# Altium schematic sheet 04_AudioCodec.SchDoc
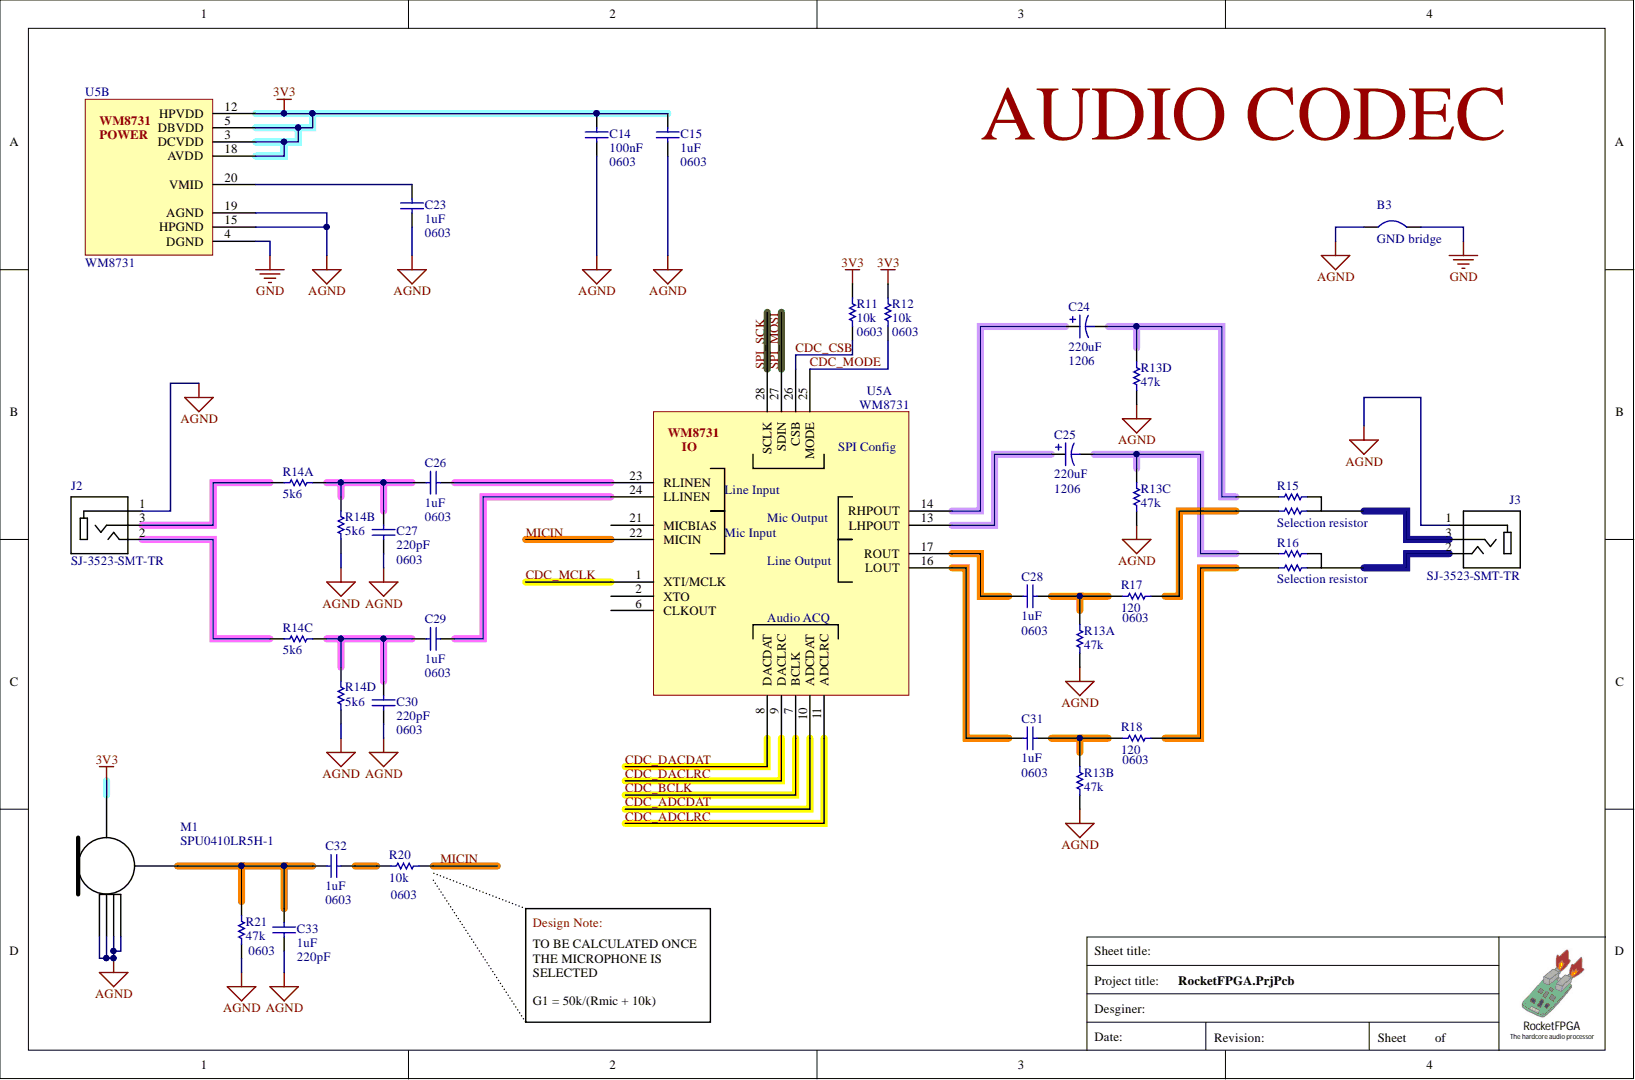
[diagram p0: 04_AudioCodec.SchDoc]

## Parts
B3  GND bridge  [Bridge]
C14  value 100nF  Package: 0603  [0603 CAP]  (hidden comment: Ceramic capacitor)
C15  value 1uF  Package: 0603  [0603 CAP]  (hidden comment: Ceramic capacitor)
C23  value 1uF  Package: 0603  [0603 CAP]  (hidden comment: Ceramic capacitor)
C24  value 220uF  Package: 1206  [CAPCP3216X16N]  (hidden comment: Capacitor Electrolitic)
C25  value 220uF  Package: 1206  [CAPCP3216X16N]  (hidden comment: Capacitor Electrolitic)
C26  value 1uF  [0603 CAP]  (hidden comment: Ceramic capacitor)
C27  value 220pF  Package: 0603  [0603 CAP]  (hidden comment: Ceramic capacitor)
C28  value 1uF  Package: 0603  [0603 CAP]  (hidden comment: Ceramic capacitor)
C29  value 1uF  Package: 0603  [0603 CAP]  (hidden comment: Ceramic capacitor)
C30  value 220pF  Package: 0603  [0603 CAP]  (hidden comment: Ceramic capacitor)
C31  value 1uF  Package: 0603  [0603 CAP]  (hidden comment: Ceramic capacitor)
C32  value 1uF  Package: 0603  [0603 CAP]  (hidden comment: Ceramic capacitor)
C33  value 1uF  Package: 220pF  [0603 CAP]  (hidden comment: Ceramic capacitor)
J2  SJ-3523-SMT-TR  [CUI_SJ-3523-SMT-TR]
J3  SJ-3523-SMT-TR  [CUI_SJ-3523-SMT-TR]
M1  SPU0410LR5H-1  [SPU0410LR5H-1]
R11  value 10k  Package: 0603  [Resistencia 0603]  (hidden comment: Resistor)
R12  value 10k  Package: 0603  [Resistencia 0603]  (hidden comment: Resistor)
R13  value 47k  (hidden comment: Resistor array)  (parts A,B,C,D)
R14  value 5k6  (hidden comment: Resistor array)  (parts A,B,C,D)
R15  Selection resistor
R16  Selection resistor
R17  value 120  [Resistencia 0603]  (hidden comment: Resistor)
R18  value 120  Package: 0603  [Resistencia 0603]  (hidden comment: Resistor)
R20  value 10k  Package: 0603  [Resistencia 0603]  (hidden comment: Resistor)
R21  value 47k  Package: 0603  [Resistencia 0603]  (hidden comment: Resistor)
U5  WM8731  [WM8731]  (parts A,B)

## Nets
3V3: C14.1 C15.1 M1.4 R11.2 R12.2 U5.3(DCVDD) U5.5(DBVDD) U5.12(HPVDD) U5.18(AVDD)
AGND: B3.1 C14.2 C15.2 C23.2 C27.2 C30.2 C33.2 J2.1 J3.1 M1.2 M1.3 M1.5 M1.6 R13.5 R13.6 R13.7 R13.8 R14.2 R14.4 R21.1 U5.15(HPGND) U5.19(AGND)
GND: B3.2 U5.4(DGND)
CDC_ADCDAT: U5.10(ADCDAT)
CDC_ADCLRC: U5.11(ADCLRC)
CDC_BCLK: U5.7(BCLK)
CDC_CSB: R11.1 U5.26(CSB)
CDC_DACDAT: U5.8(DACDAT)
CDC_DACLRC: U5.9(DACLRC)
CDC_MCLK: U5.1(XTI/MCLK)
CDC_MODE: R12.1 U5.25(MODE)
MICIN: R20.2 U5.22(MICIN)
SPI_MOSI: U5.27(SDIN)
SPI_SCK: U5.28(SCLK)
NetC23_1: C23.1 U5.20(VMID)
NetC24_1: C24.1 U5.14(RHPOUT)
NetC24_2: C24.2 R13.4 R15.1
NetC25_1: C25.1 U5.13(LHPOUT)
NetC25_2: C25.2 R13.3 R16.1
NetC26_1: C26.1 C27.1 R14.7 R14.8
NetC26_2: C26.2 U5.23(RLINEN)
NetC28_1: C28.1 U5.17(ROUT)
NetC28_2: C28.2 R13.1 R17.1
NetC29_1: C29.1 U5.24(LLINEN)
NetC29_2: C29.2 C30.1 R14.5 R14.6
NetC31_1: C31.1 U5.16(LOUT)
NetC31_2: C31.2 R13.2 R18.1
NetC32_1: C32.1 R20.1
NetC32_2: C32.2 C33.1 M1.1 R21.2
NetJ2_2: J2.2 R14.3
NetJ2_3: J2.3 R14.1
NetJ3_2: J3.2 R16.2
NetJ3_3: J3.3 R15.2
NetR15_3: R15.3 R17.2
NetR16_3: R16.3 R18.2

Not connected: U5 2(XTO) 6(CLKOUT) 21(MICBIAS)

## Library data of the parts
B3 = Bridge: Component Kind: Net Tie; Library Name: NodeFPGA.SCHLIB
C14 = Capacitor: vendor: LCSC; vendorID: 0603F104M500NT; Component Kind: Standard; Description: Capacitor; Library Name: NodeFPGA.SCHLIB; ManufacturerReference: -; price: 0.0023; Signal Integrity: Cap; Simulation: CAP; Supplier 1: Mouser; Supplier Part Number 1: 80-C1206C104K5R
C15 C23 C26 C28 C29 C31-C33 = Capacitor: vendor: LCSC; vendorID: CC0603ZRY5V7BB105; Component Kind: Standard; Description: Capacitor; Library Name: NodeFPGA.SCHLIB; ManufacturerReference: -; price: 0.0053; Signal Integrity: Cap; Simulation: CAP; Supplier 1: Mouser; Supplier Part Number 1: 80-C1206C104K5R
  C26: Package: 0603
C24 C25 = Capacitor Electrolitic: vendor: Mouser; vendorID: 80-T491A224M035; Voltage Rating: 10V; Component Kind: Standard; Date: 21/05/2009; Description: Capacitor Electrolitic; Library Name: NodeFPGA.SCHLIB; ManufacturerReference: -; price: 0.38; Author: Jaime Jiménez Ortega
C27 C30 = Capacitor: vendor: LCSC; vendorID: 0603B221K500NT; Component Kind: Standard; Description: Capacitor; Library Name: NodeFPGA.SCHLIB; ManufacturerReference: -; price: 0.0035; Signal Integrity: Cap; Simulation: CAP; Supplier 1: Mouser; Supplier Part Number 1: 80-C1206C104K5R
J2 J3 = SJ-3523-SMT-TR: vendor: Mouser; vendorID: 490-SJ-3523-SMT-TR; Component Kind: Standard; Library Name: NodeFPGA.SCHLIB; ManufacturerReference: SJ-3523-SMT-TR; Package: -; price: 0.777
M1 = SPU0410LR5H-1: MEMS Microphone; ManufacturerReference: SPU0410LR5H-QB; vendor: Mouser; vendorID: 721-SPU0410LR5H-QB; Component Kind: Standard; Library Name: NodeFPGA.SCHLIB; price: 0.646
R11 R12 R20 = Resistor: vendor: LCSC; vendorID: 0603WAF1002T5E; Component Kind: Standard; Description: Resistor; Library Name: NodeFPGA.SCHLIB; ManufacturerReference: -; price: 0.0009; Signal Integrity: Res; Simulation: RESISTOR; Supplier 1: Farnell; Supplier Part Number 1: 2358436
R13 = ResistorArray_4: 4 resistors in smd array configuration; ManufacturerReference: TC164-FR-0747KL; vendor: Mouser; vendorID: 603-TC164-FR-0747KL; Component Kind: Standard; Library Name: NodeFPGA.SCHLIB; Package: -; price: 0.201
R14 = ResistorArray_4: 4 resistors in smd array configuration; ManufacturerReference: TC164-FR-075K6L; vendor: Mouser; vendorID: 603-TC164-FR-075K6L; Component Kind: Standard; Library Name: NodeFPGA.SCHLIB; Package: -; price: 0.201
R15 R16 = SelectionResistor: Component Kind: Standard; Library Name: NodeFPGA.SCHLIB
R17 R18 = Resistor: vendor: LCSC; vendorID: CR0603FA1200G; Component Kind: Standard; Description: Resistor; Library Name: NodeFPGA.SCHLIB; ManufacturerReference: -; price: 0.0024; Signal Integrity: Res; Simulation: RESISTOR; Supplier 1: Farnell; Supplier Part Number 1: 2358436
  R17: Package: 0603
R21 = Resistor: vendor: LCSC; vendorID: RS-03K473JT; Component Kind: Standard; Description: Resistor; Library Name: NodeFPGA.SCHLIB; ManufacturerReference: -; price: 0.0007; Signal Integrity: Res; Simulation: RESISTOR; Supplier 1: Farnell; Supplier Part Number 1: 2358436
U5 = WM8731: Portable Internet Audio CODEC with Headphone Driver  and Programmable Sample Rates; vendor: Mouser; vendorID: 238-WM8731CLSEFL; Component Kind: Standard; Library Name: NodeFPGA.SCHLIB; ManufacturerReference: WM8731; Package: PQFN 28 Leads; price: 3.18
